# Altium schematic sheet UART_Level_Shift.SchDoc
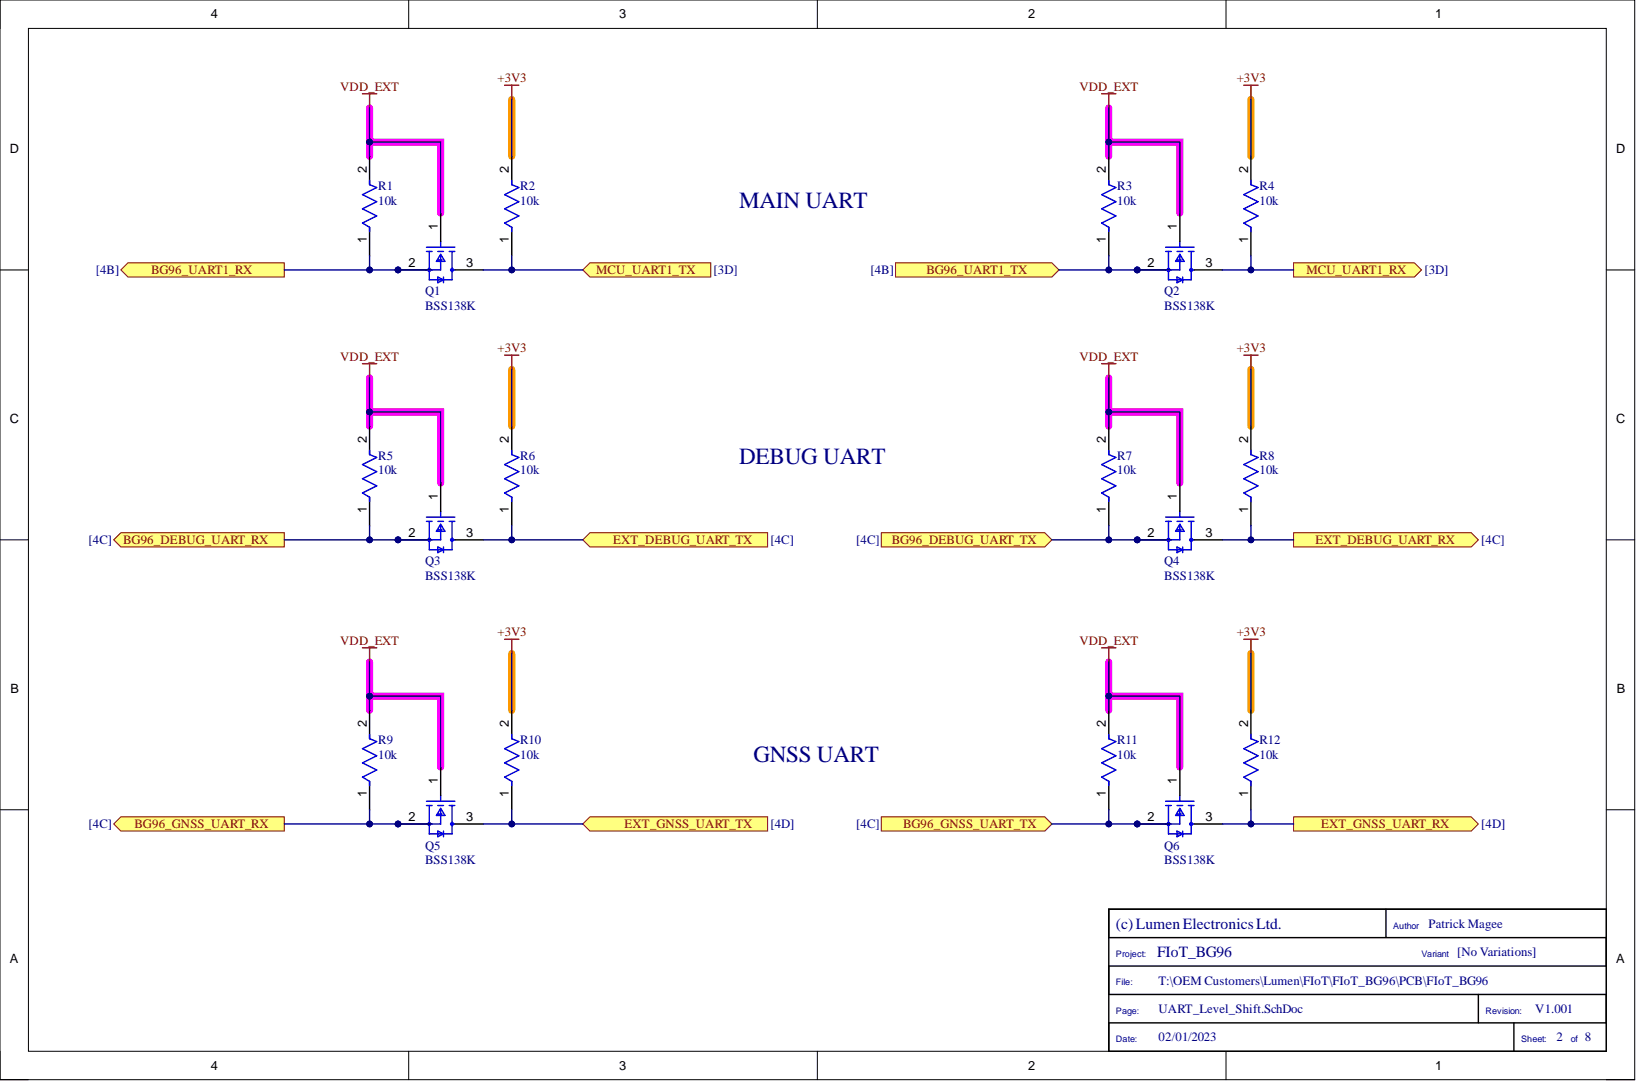
[diagram p0: UART_Level_Shift.SchDoc]

## Parts
Q1  BSS138K  [FP-318-08-IPC_B]
Q2  BSS138K  [FP-318-08-IPC_B]
Q3  BSS138K  [FP-318-08-IPC_B]
Q4  BSS138K  [FP-318-08-IPC_B]
Q5  BSS138K  [FP-318-08-IPC_B]
Q6  BSS138K  [FP-318-08-IPC_B]
R1  Resistance: 10k  [FP-CRCW0402-e3-MFG]  (hidden comment: 0402WGF1002TCE)
R2  Resistance: 10k  [FP-CRCW0402-e3-MFG]  (hidden comment: 0402WGF1002TCE)
R3  Resistance: 10k  [FP-CRCW0402-e3-MFG]  (hidden comment: 0402WGF1002TCE)
R4  Resistance: 10k  [FP-CRCW0402-e3-MFG]  (hidden comment: 0402WGF1002TCE)
R5  Resistance: 10k  [FP-CRCW0402-e3-MFG]  (hidden comment: 0402WGF1002TCE)
R6  Resistance: 10k  [FP-CRCW0402-e3-MFG]  (hidden comment: 0402WGF1002TCE)
R7  Resistance: 10k  [FP-CRCW0402-e3-MFG]  (hidden comment: 0402WGF1002TCE)
R8  Resistance: 10k  [FP-CRCW0402-e3-MFG]  (hidden comment: 0402WGF1002TCE)
R9  Resistance: 10k  [FP-CRCW0402-e3-MFG]  (hidden comment: 0402WGF1002TCE)
R10  Resistance: 10k  [FP-CRCW0402-e3-MFG]  (hidden comment: 0402WGF1002TCE)
R11  Resistance: 10k  [FP-CRCW0402-e3-MFG]  (hidden comment: 0402WGF1002TCE)
R12  Resistance: 10k  [FP-CRCW0402-e3-MFG]  (hidden comment: 0402WGF1002TCE)

## Ports
BG96_DEBUG_UART_RX, BG96_DEBUG_UART_TX, BG96_GNSS_UART_RX, BG96_GNSS_UART_TX, BG96_UART1_RX, BG96_UART1_TX, EXT_DEBUG_UART_RX, EXT_DEBUG_UART_TX, EXT_GNSS_UART_RX, EXT_GNSS_UART_TX, MCU_UART1_RX, MCU_UART1_TX

## Nets
+3V3: R2.2 R4.2 R6.2 R8.2 R10.2 R12.2
VDD_EXT: Q1.1 Q2.1 Q3.1 Q4.1 Q5.1 Q6.1 R1.2 R3.2 R5.2 R7.2 R9.2 R11.2
BG96_DEBUG_UART_RX: Q3.2 R5.1 ; port BG96_DEBUG_UART_RX
BG96_DEBUG_UART_TX: Q4.2 R7.1 ; port BG96_DEBUG_UART_TX
BG96_GNSS_UART_RX: Q5.2 R9.1 ; port BG96_GNSS_UART_RX
BG96_GNSS_UART_TX: Q6.2 R11.1 ; port BG96_GNSS_UART_TX
BG96_UART1_RX: Q1.2 R1.1 ; port BG96_UART1_RX
BG96_UART1_TX: Q2.2 R3.1 ; port BG96_UART1_TX
EXT_DEBUG_UART_RX: Q4.3 R8.1 ; port EXT_DEBUG_UART_RX
EXT_DEBUG_UART_TX: Q3.3 R6.1 ; port EXT_DEBUG_UART_TX
EXT_GNSS_UART_RX: Q6.3 R12.1 ; port EXT_GNSS_UART_RX
EXT_GNSS_UART_TX: Q5.3 R10.1 ; port EXT_GNSS_UART_TX
MCU_UART1_RX: Q2.3 R4.1 ; port MCU_UART1_RX
MCU_UART1_TX: Q1.3 R2.1 ; port MCU_UART1_TX

## Library data of the parts
Q1-Q6 = BSS138K: MOSFET N-CH 50V 220MA SOT-23; Manufacturer: ON Semiconductor / Fairchild; Manufacturer Part Number: BSS138; Drain to Source Resistance: 3.5R; Element Configuration: Single; Fall Time: 9ns; Gate to Source Voltage (Vgs): 20V; Input Capacitance: 27pF; Max Power Dissipation: 360mW; Nominal Vgs: 1.3V; Number of Elements: 1; On-State Resistance: 6R; Turn-Off Delay Time: 20ns; Turn-On Delay Time: 2.5ns; Case/Package: SOT-23; Component Kind: Standard; Contact Plating: Tin; Continuous Drain Current (ID): 220mA; Current Rating: 220mA; Drain to Source Breakdown Voltage: 50V; Drain to Source Voltage (Vdss): 50V; Dual Supply Voltage: 50V; Height: 0.93mm; JLC Footprint: SOT-23-3; JLCPCB Part #: C52895; Lead Free: Lead Free; Length: 2.92mm; Library Name: FIoT_BG96.SCHLIB; Max Operating Temperature: 150°C; MFG Name: ON Semiconductor / Fairchild; MFG Part Number: BSS138; Min Operating Temperature: -55°C; Mount: Surface Mount; Number of Pins: 3; Package Quantity: 1; Packaging: Tape and Reel; Power Dissipation: 360mW; Radiation Hardening: No; Rds On Max: 3.5R; REACH SVHC: No SVHC; Rise Time: 9ns; Supplier 1: Digi-Key; Supplier 2: Farnell; Supplier 3: Mouser; Supplier Part Number 1: BSS138K-13DICT-ND; Supplier Part Number 2: 3943470; Supplier Part Number 3: 621-BSS138K-13; Termination: SMD/SMT; Threshold Voltage: 1.3V; Voltage Rating (DC): 50V; Weight: 0.03g; Width: 1.3mm
R1-R12 = 0402WGF1002TCE: Res Thick Film 0402 10K Ohm 1% 0.063W; Manufacturer: Vishay Dale; Manufacturer Part Number: CRCW04021K00FKED; Max Power Dissipation: 63mW; Temperature Coefficient: 100ppm/°C; Voltage Rating: 50V; Case Code (Imperial): 0402; Case Code (Metric): 1005; Case/Package: 0402; Component Kind: Standard; Composition: Thick Film; Contact Plating: Tin; Depth: 0.5mm; Features: Automotive AEC-Q200; Height: 0.35mm; JLC Footprint: R0402; JLCPCB Part #: Not available with JLC; Lead Free: Lead Free; Length: 1mm; Library Name: FIoT_BG96.SCHLIB; Max Operating Temperature: 155°C; MFG Name: Vishay Dale; MFG Part Number: CRCW04021K00FKED; Military Standard: Not; Min Operating Temperature: -55°C; Mount: Surface Mount; Number of Pins: 2; Number of Terminations: 2; Package Quantity: 10; Packaging: Tape and Reel; Power Rating: 63mW; Radiation Hardening: No; Supplier 1: Mouser; Supplier 2: LCSC; Supplier Part Number 1: 303-0402WGF1002TCE; Supplier Part Number 2: C25744; Termination: SMD/SMT; Tolerance: 1%; Voltage Rating (DC): 50V; Weight: 2.3E-05oz; Width: 0.5mm
